# Altium schematic sheet TempPress.SchDoc
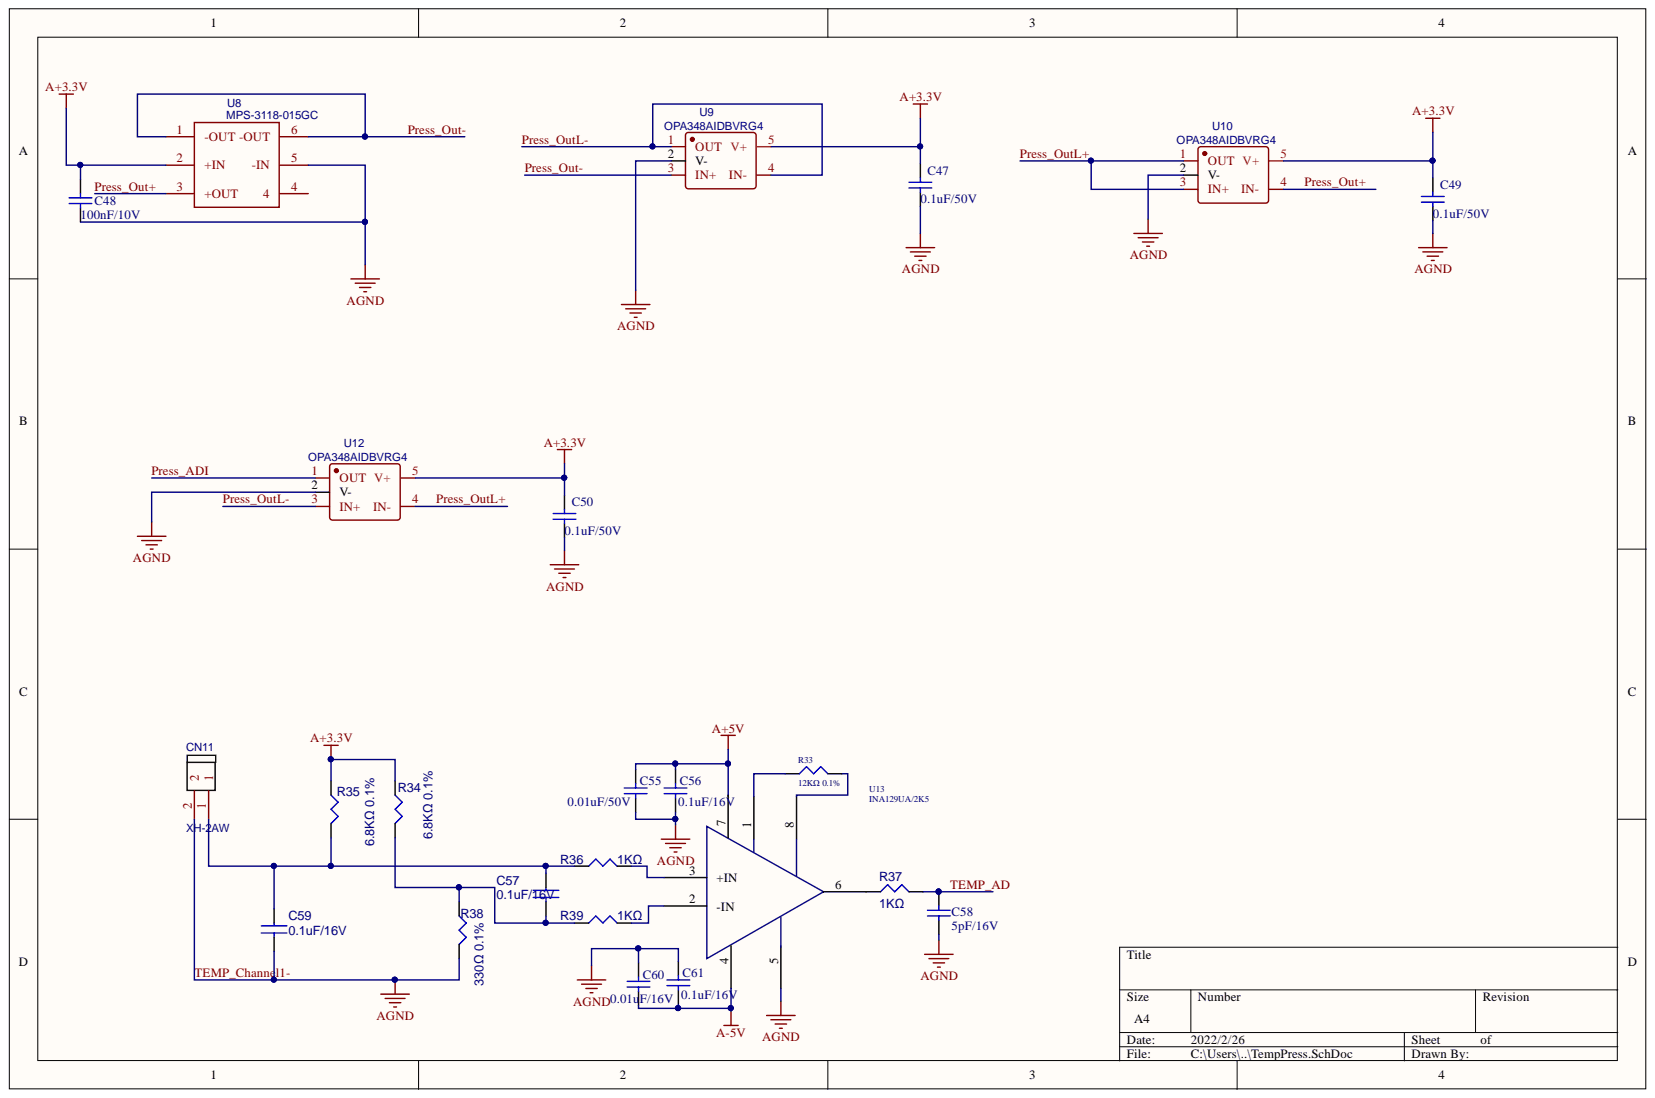
[diagram p0: TempPress.SchDoc]

## Parts
C47  0.1uF/50V  [C0603]
C48  100nF/10V  [C0603]
C49  0.1uF/50V  [C0603]
C50  0.1uF/50V  [C0603]
C55  0.01uF/50V  [C0603]
C56  0.1uF/16V  [C0603]
C57  0.1uF/16V  [C0603]
C58  5pF/16V  [C0603]
C59  0.1uF/16V  [C0603]
C60  0.01uF/16V  [C0603]
C61  0.1uF/16V  [C0603]
CN11  XH-2AW  [XH-2P]
R33  12KΩ 0.1%  [R0603]
R34  6.8KΩ 0.1%  [R0603]
R35  6.8KΩ 0.1%  [R0603]
R36  1KΩ  [R0603]
R37  1KΩ  [R0603]
R38  330Ω 0.1%  [R0603]
R39  1KΩ  [R0603]
U8  MPS-3118-015GC  [SMD]
U9  OPA348AIDBVRG4  [SOT-23-5]
U10  OPA348AIDBVRG4  [SOT-23-5]
U12  OPA348AIDBVRG4  [SOT-23-5]
U13  INA129UA/2K5  [SOIC-8]

## Nets
A+3.3V: C47.2 C48.2 C49.2 C50.2 R34.1 R35.1 U8.2(+IN) U9.5(V+) U10.5(V+) U12.5(V+)
A+5V: C55.2 C56.2 U13.7
A-5V: C60.1 C61.1 U13.4
Press_ADI: U12.1(OUT)
Press_Out+: U8.3(+OUT) U10.4(IN-)
Press_Out-: U8.1(-OUT) U8.6(-OUT) U9.3(IN+)
Press_OutL+: U10.1(OUT) U10.3(IN+) U12.4(IN-)
Press_OutL-: U9.1(OUT) U9.4(IN-) U12.3(IN+)
TEMP_AD: C58.2 R37.2
TEMP_Channel1-: C47.1 C48.1 C49.1 C50.1 C55.1 C56.1 C58.1 C59.2 C60.2 C61.2 CN11.2 R38.2 U8.5(-IN) U9.2(V-) U10.2(V-) U12.2(V-) U13.5
NetC57_1: C57.1 C59.1 CN11.1 R35.2 R36.1
NetC57_2: C57.2 R34.2 R38.1 R39.1
NetR33_1: R33.1 U13.8
NetR33_2: R33.2 U13.1
NetR36_2: R36.2 U13.3(+IN)
NetR37_1: R37.1 U13.6
NetR39_2: R39.2 U13.2(-IN)

Not connected: U8 4

## Library data of the parts
C47-C50 = Cap: Capacitor; Value: 10uF/10V; Component Kind: Standard; LatestRevisionDate: 17-Jul-2002; LatestRevisionNote: Re-released for DXP Platform.; Library Name: LIB-T.SCHLIB; PackageDescription: Radial Cap, Thru-Hole; 2 Leads; 0.3 in Pin Spacing; PackageReference: RAD-0.3; Published: 8-Jun-2000; Simulation: CAP; Publisher: Altium Limited
C55 C56 C58 C60 C61 = 0402 10nF (103) 10% 50V: 贴片电容; Component Kind: Standard; ComponentLink1Description: 供应商链接; ComponentLink1URL: http://www.szlcsc.com/product/details_15869.html; Library Name: Cap.SchLib; Package: 0402; Supplier: LC; SuppliersPartNumber: C15195
C57 C59 = CAP NP 0805: Component Kind: Standard; Library Name: C-LifeReal.SchLib
CN11 = XH-2AW: BOM_Manufacturer: BOOMELE; BOM_JLCPCB Part Class: Extended Part; BOM_Manufacturer Part: XH-2AW; BOM_Supplier: LCSC; BOM_Supplier Part: C33132; Component Kind: Standard; spicePre: C; spiceSymbolName: XH-2AW
R33 = RES AXIAL0.3: Component Kind: Standard; Library Name: C-LifeReal.SchLib
R34-R39 = RES 0805: Component Kind: Standard; Library Name: C-LifeReal.SchLib
U8 = MPS-3118-015GC: BOM_Manufacturer: Metrodyne; BOM_JLCPCB Part Class: Extended Part; BOM_Manufacturer Part: MPS-3118-015GC; BOM_Supplier: LCSC; BOM_Supplier Part: C114719; Component Kind: Standard; spicePre: U; spiceSymbolName: MPS-3118-015GC
U9 U10 U12 = OPA348AIDBVRG4: BOM_Manufacturer: TI; SMT Type: Extend; BOM_JLCPCB SMD: Yes; BOM_Manufacturer Part: OPA348AIDBVRG4; BOM_Supplier: LCSC; BOM_Supplier Part: C46142; Component Kind: Standard; image: //image.lceda.cn/szlcsc/C46142.jpg; spicePre: U; spiceSymbolName: OPA348AIDBVRG4
U13 = AD620: Component Kind: Standard; Library Name: Integrated_Library1.IntLib
